# Altium schematic sheet Temp_Zasilanie.SchDoc(Temp_Zasilanie)
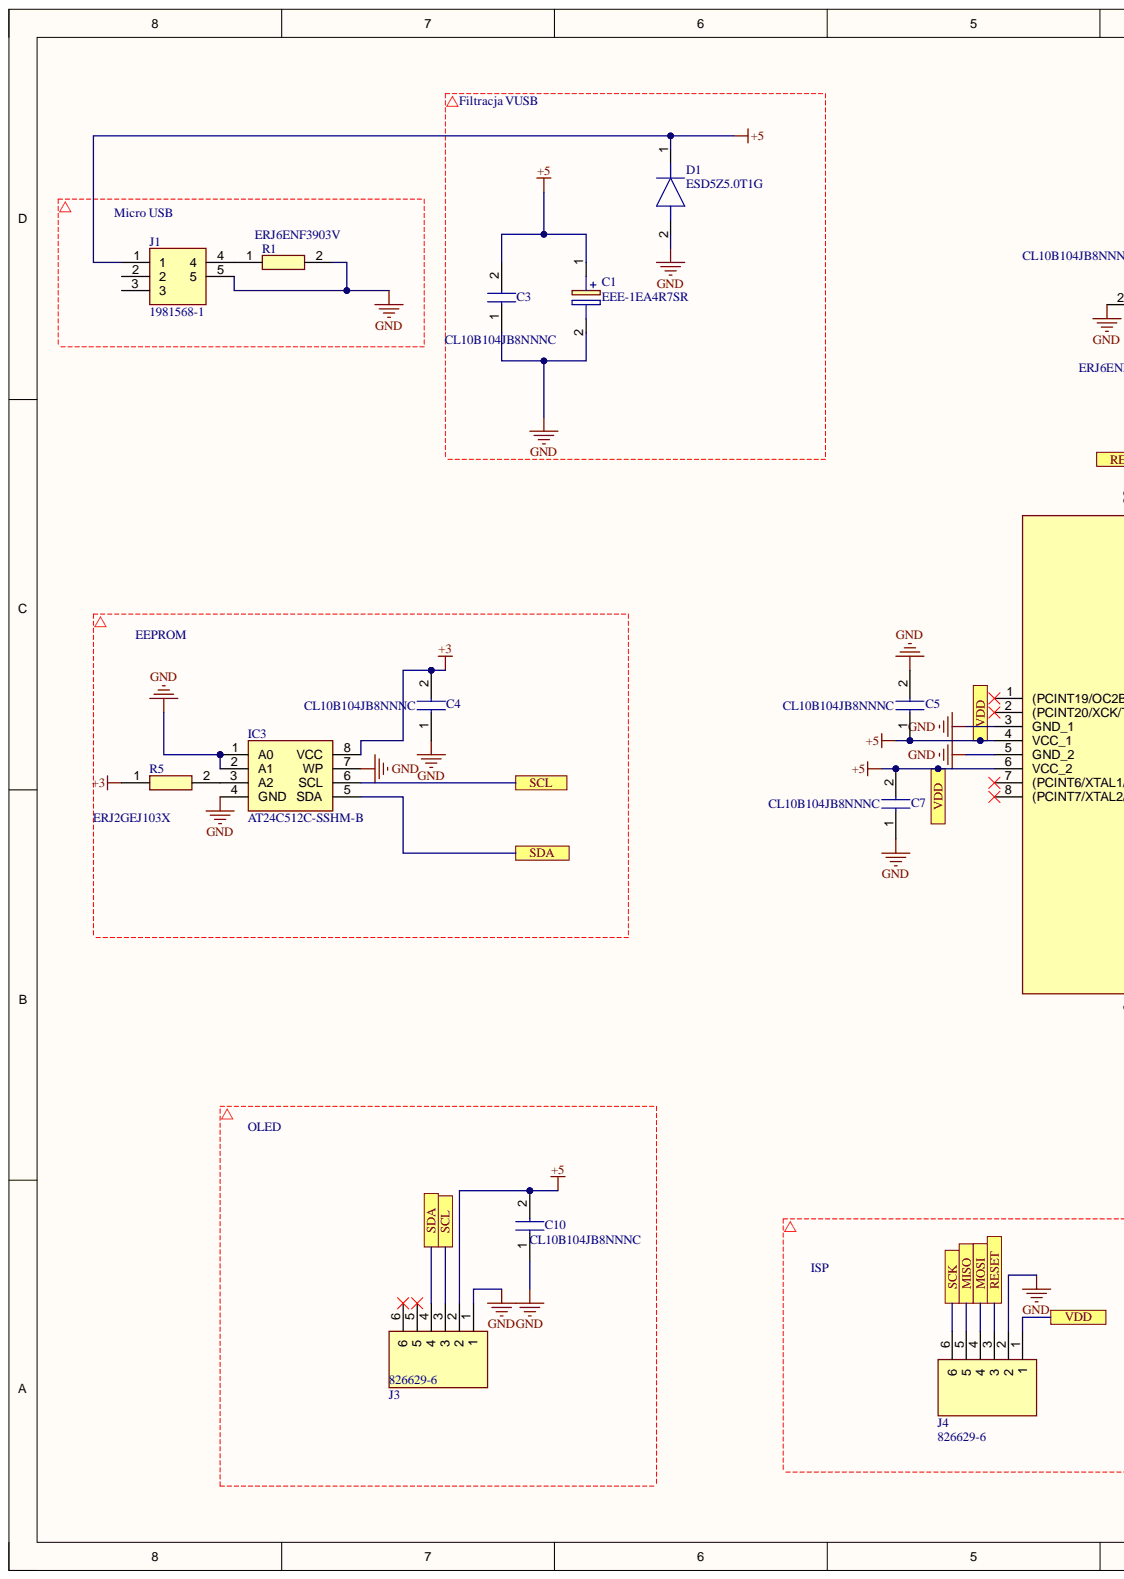
[diagram p0: Temp_Zasilanie.SchDoc, left]
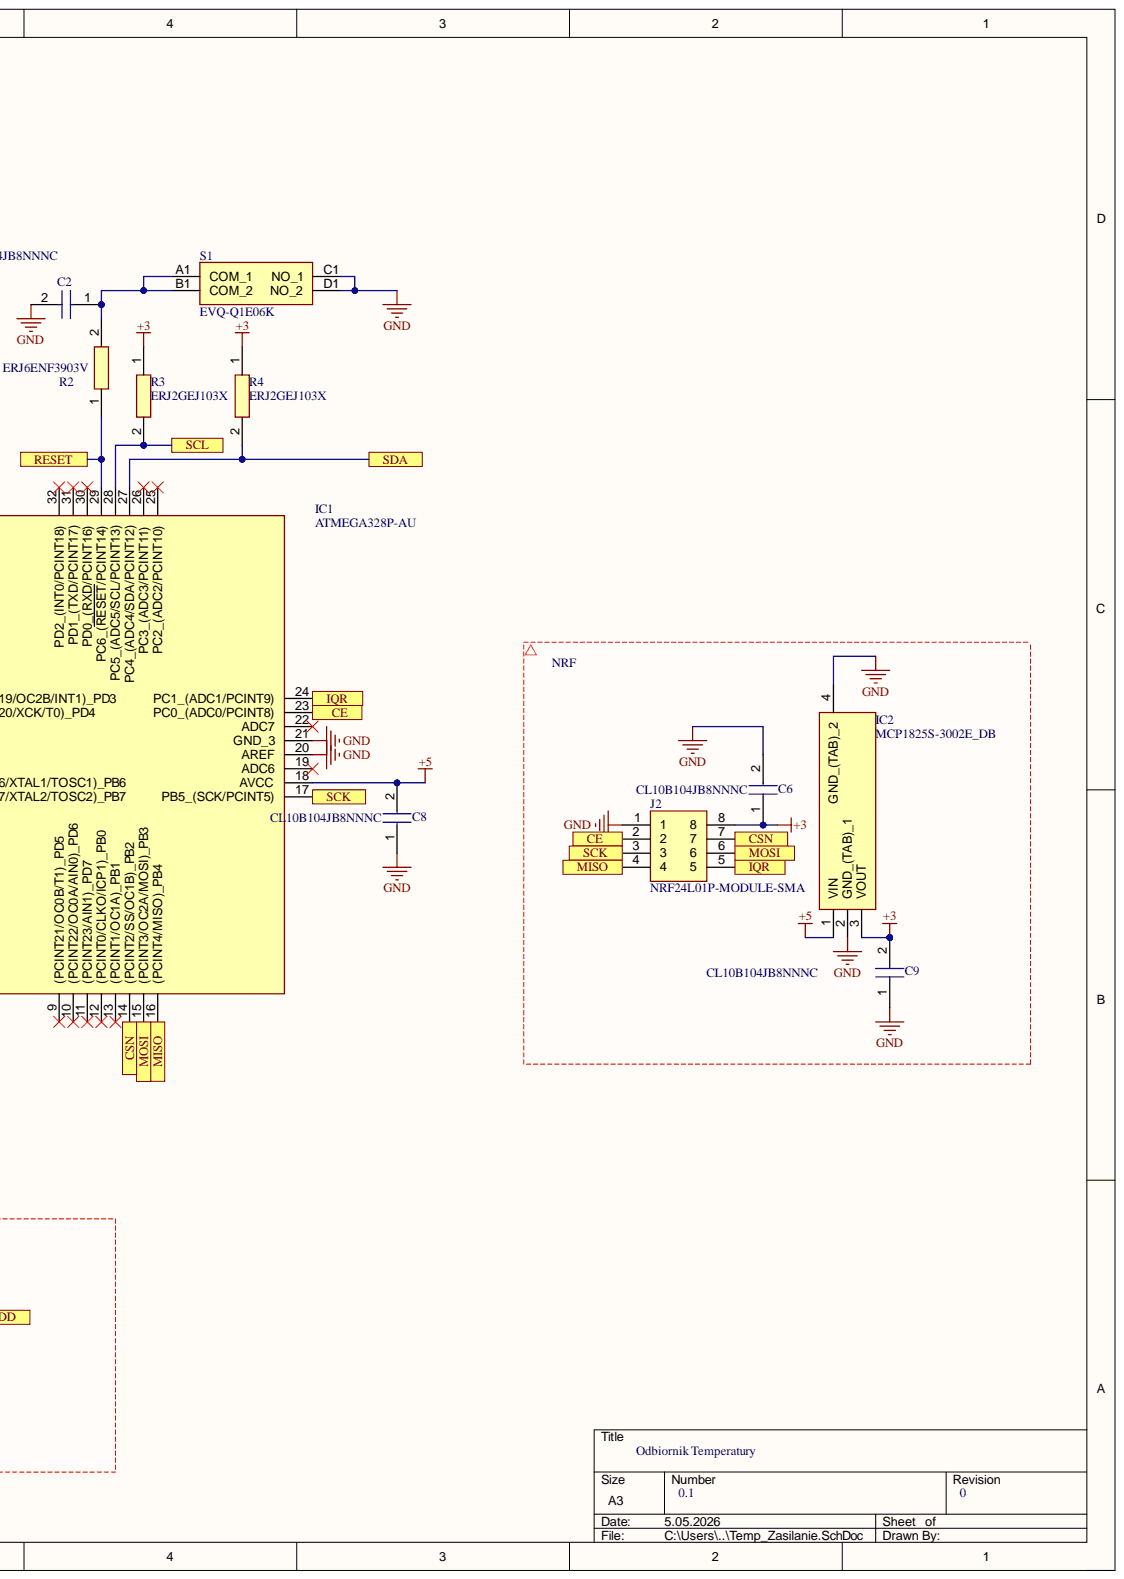
[diagram p1: Temp_Zasilanie.SchDoc, right]

## Parts
C1  EEE-1EA4R7SR  [EEE1AA220WR]
C2  CL10B104JB8NNNC  [CAPC1608X90N]
C3  CL10B104JB8NNNC  [CAPC1608X90N]
C4  CL10B104JB8NNNC  [CAPC1608X90N]
C5  CL10B104JB8NNNC  [CAPC1608X90N]
C6  CL10B104JB8NNNC  [CAPC1608X90N]
C7  CL10B104JB8NNNC  [CAPC1608X90N]
C8  CL10B104JB8NNNC  [CAPC1608X90N]
C9  CL10B104JB8NNNC  [CAPC1608X90N]
C10  CL10B104JB8NNNC  [CAPC1608X90N]
D1  ESD5Z5.0T1G  [SODFL1608X70N]
IC1  ATMEGA328P-AU  [QFP80P900X900X120-32N]
IC2  MCP1825S-3002E_DB  [SOT230P700X180-4N]
IC3  AT24C512C-SSHM-B  [SOIC127P600X175-8N]
J1  1981568-1  [1981568-1]
J2  NRF24L01P-MODULE-SMA  [NRF24L01PMODULESMA]
J3  826629-6  [HDRV6W63P0X254_1X6_1524X250X950P]
J4  826629-6  [HDRV6W63P0X254_1X6_1524X250X950P]
R1  ERJ6ENF3903V  [ERJ6ENF1000V]
R2  ERJ6ENF3903V  [ERJ6ENF1000V]
R3  ERJ2GEJ103X  [ERJ2RKD1004X]
R4  ERJ2GEJ103X  [ERJ2RKD1004X]
R5  ERJ2GEJ103X  [ERJ2RKD1004X]
S1  EVQ-Q1E06K  [EVQQ1E06K]

## Ports
CE, CSN, IQR, MISO, MOSI, RESET, SCK, SCL, SDA, VDD

## Nets
+3: C4.2 C6.1 C9.2 IC2.3(VOUT) IC3.8(VCC) J2.8 R3.1 R4.1 R5.1
GND: C1.2 C2.2 C3.1 C4.1 C5.2 C6.2 C7.1 C8.1 C9.1 C10.1 D1.2 IC1.3(GND_1) IC1.5(GND_2) IC1.20(AREF) IC1.21(GND_3) IC2.2(GND_(TAB)_1) IC2.4(GND_(TAB)_2) IC3.1(A0) IC3.2(A1) IC3.4(GND) IC3.7(WP) J1.5 J2.1 J3.1 J4.2 R1.2 S1.C1(NO_1) S1.D1(NO_2)
+5: C1.1 C3.2 C5.1 C7.2 C8.2 C10.2 D1.1 IC1.4(VCC_1) IC1.6(VCC_2) IC1.18(AVCC) IC2.1(VIN) J1.1 J3.2 J4.1 ; port VDD
CE: IC1.23(PC0_(ADC0/PCINT8)) J2.2 ; port CE
CSN: IC1.14((PCINT2/SS/OC1B)_PB2) J2.7 ; port CSN
IQR: IC1.24(PC1_(ADC1/PCINT9)) J2.5 ; port IQR
MISO: IC1.16((PCINT4/MISO)_PB4) J2.4 J4.5 ; port MISO
MOSI: IC1.15((PCINT3/OC2A/MOSI)_PB3) J2.6 J4.4 ; port MOSI
RESET: IC1.29(PC6_(RESET/PCINT14)) J4.3 R2.1 ; port RESET
SCK: IC1.17(PB5_(SCK/PCINT5)) J2.3 J4.6 ; port SCK
SCL: IC1.28(PC5_(ADC5/SCL/PCINT13)) IC3.6(SCL) J3.3 R3.2 ; port SCL
SDA: IC1.27(PC4_(ADC4/SDA/PCINT12)) IC3.5(SDA) J3.4 R4.2 ; port SDA
NetC2_1: C2.1 R2.2 S1.A1(COM_1) S1.B1(COM_2)
NetIC3_3: IC3.3(A2) R5.2
NetJ1_4: J1.4 R1.1

Not connected: IC1 1((PCINT19/OC2B/INT1)_PD3) 2((PCINT20/XCK/T0)_PD4) 7((PCINT6/XTAL1/TOSC1)_PB6) 8((PCINT7/XTAL2/TOSC2)_PB7) 9((PCINT21/OC0B/T1)_PD5) 10((PCINT22/OC0A/AIN0)_PD6) 11((PCINT23/AIN1)_PD7) 12((PCINT0/CLKO/ICP1)_PB0) 13((PCINT1/OC1A)_PB1) 19(ADC6) 22(ADC7) 25(PC2_(ADC2/PCINT10)) 26(PC3_(ADC3/PCINT11)) 30(PD0_(RXD/PCINT16)) 31(PD1_(TXD/PCINT17)) 32(PD2_(INT0/PCINT18)); J1 2 3; J3 5 6

## Library data of the parts
C1 = EEE-1EA4R7SR: Capacitor Polarised; Manufacturer_Name: Panasonic; Arrow Part Number: EEE-1EA4R7SR; Arrow Price/Stock: https://www.arrow.com/en/products/eee-1ea4r7sr/panasonic?region=nac; Component Kind: Standard; Datasheet Link: http://industrial.panasonic.com/cdbs/www-data/pdf/RDE0000/ABA0000C1145.pdf; Height: 5.5mm; Manufacturer_Part_Number: EEE-1EA4R7SR; Mouser Part Number: 667-EEE-1EA4R7SR; Mouser Price/Stock: https://www.mouser.co.uk/ProductDetail/Panasonic/EEE-1EA4R7SR?qs=ANJC8C65Mw5Ie6rFZ1MXbA%3D%3D; Signal Integrity: Guessed Model
C2-C10 = CL10B104JB8NNNC: Capacitor; Manufacturer_Name: SAMSUNG; Arrow Part Number: CL10B104JB8NNNC; Arrow Price/Stock: https://www.arrow.com/en/products/cl10b104jb8nnnc/samsung-electro-mechanics?region=nac; Component Kind: Standard; Datasheet Link: https://datasheet.datasheetarchive.com/originals/distributors/Datasheets_SAMA/dd149ce8a6679b37799cedb31427d43d.pdf; Height: 0.9mm; Manufacturer_Part_Number: CL10B104JB8NNNC; Mouser Part Number: 187-CL10B104JB8NNNC; Mouser Price/Stock: https://www.mouser.co.uk/ProductDetail/Samsung-Electro-Mechanics/CL10B104JB8NNNC?qs=349EhDEZ59oLEw5wc1qvGw%3D%3D
  C2-C7: Signal Integrity: Guessed Model
D1 = ESD5Z5.0T1G: Diode; Manufacturer_Name: onsemi; Arrow Part Number: ESD5Z5.0T1G; Arrow Price/Stock: https://www.arrow.com/en/products/esd5z5.0t1g/on-semiconductor?region=nac; Component Kind: Standard; Datasheet Link: http://www.onsemi.com/pub/Collateral/ESD5Z2.5T1-D.PDF; Height: 0.7mm; Library Name: SamacSys.SchLib; Manufacturer_Part_Number: ESD5Z5.0T1G
IC1 = ATMEGA328P-AU: Integrated Circuit; Manufacturer_Name: Microchip; Arrow Part Number: ATMEGA328P-AU; Arrow Price/Stock: https://www.arrow.com/en/products/atmega328p-au/microchip-technology?region=europe; Component Kind: Standard; Datasheet Link: https://componentsearchengine.com/Datasheets/2/ATMEGA328P-AU.pdf; Height: 1.2mm; Manufacturer_Part_Number: ATMEGA328P-AU; Mouser Part Number: 556-ATMEGA328P-AU; Mouser Price/Stock: https://www.mouser.co.uk/ProductDetail/Microchip-Technology/ATMEGA328P-AU?qs=K8BHR703ZXiCmmgp6%2FGNmQ%3D%3D
IC2 = MCP1825S-3002E_DB: Integrated Circuit; Manufacturer_Name: Microchip; Manufacturer_Part_Number: MCP1825S-3002E/DB; Arrow Part Number: MCP1825S-3002E/DB; Arrow Price/Stock: https://www.arrow.com/en/products/mcp1825s-3002edb/microchip-technology?region=nac; Component Kind: Standard; Datasheet Link: http://ww1.microchip.com/downloads/en/DeviceDoc/22056b.pdf; Height: 1.8mm; Mouser Part Number: 579-MCP1825S-3002EDB; Mouser Price/Stock: https://www.mouser.co.uk/ProductDetail/Microchip-Technology/MCP1825S-3002E-DB?qs=gsqZ4L1luKo7Ksvo0w2QHA%3D%3D
IC3 = AT24C512C-SSHM-B: Integrated Circuit; Manufacturer_Name: Microchip; Arrow Part Number: AT24C512C-SSHM-B; Arrow Price/Stock: https://www.arrow.com/en/products/at24c512c-sshm-b/microchip-technology?region=europe; Component Kind: Standard; Datasheet Link: http://ww1.microchip.com/downloads/en/devicedoc/atmel-8720-seeprom-at24c512c-datasheet.pdf; Height: 1.75mm; Manufacturer_Part_Number: AT24C512C-SSHM-B; Mouser Part Number: 556-AT24C512C-SSHM-B; Mouser Price/Stock: https://www.mouser.co.uk/ProductDetail/Microchip-Technology/AT24C512C-SSHM-B?qs=rNaVQffb%252BtdhEtod1Bl9yw%3D%3D
J1 = 1981568-1: Connector; Manufacturer_Name: TE Connectivity; Arrow Part Number: 1981568-1; Arrow Price/Stock: https://www.arrow.com/en/products/1981568-1/te-connectivity?region=nac; Component Kind: Standard; Datasheet Link: https://www.te.com/commerce/DocumentDelivery/DDEController?Action=srchrtrv&DocNm=5-1773464-3&DocType=Data%20Sheet&DocLang=English&PartCntxt=1981568-1&DocFormat=pdf; Manufacturer_Part_Number: 1981568-1; Mouser Part Number: 571-1981568-1; Mouser Price/Stock: https://www.mouser.co.uk/ProductDetail/TE-Connectivity/1981568-1?qs=zxTme0yW%2FbaAfvOKTKC5hw%3D%3D
J2 = NRF24L01P-MODULE-SMA: Connector; Manufacturer_Name: Nordic Semiconductor; Arrow Part Number: NRF24L01P-MODULE-SMA; Arrow Price/Stock: https://www.arrow.com/en/products/nrf24l01p-module-sma/nordic-semiconductor?region=nac; Component Kind: Standard; Datasheet Link: https://infocenter.nordicsemi.com/pdf/nRF24L01P_PS_v1.0.pdf; Height: 4mm; Library Name: SamacSys.SchLib; Manufacturer_Part_Number: NRF24L01P-MODULE-SMA
J3 J4 = 826629-6: Connector; Manufacturer_Name: TE Connectivity; Arrow Part Number: 826629-6; Arrow Price/Stock: https://www.arrow.com/en/products/826629-6/te-connectivity?region=europe; Component Kind: Standard; Datasheet Link: https://componentsearchengine.com/Datasheets/2/826629-6.pdf; Height: 9.5mm; Library Name: SamacSys.SchLib; Manufacturer_Part_Number: 826629-6
R1 R2 = ERJ6ENF3903V: Resistor; Manufacturer_Name: Panasonic; Component Kind: Standard; Datasheet Link: http://docs-europe.electrocomponents.com/webdocs/13ba/0900766b813ba21b.pdf; Height: 0.7mm; Manufacturer_Part_Number: ERJ6ENF3903V
  R1: Library Name: SamacSys.SchLib
  R2: Simulation: R_Resistor_Default
R3-R5 = ERJ-2GEJ3R3X: Resistor; Manufacturer_Name: Panasonic; Arrow Part Number: ERJ-2GEJ3R3X; Arrow Price/Stock: https://www.arrow.com/en/products/erj-2gej3r3x/panasonic; Component Kind: Standard; Datasheet Link: https://datasheet.datasheetarchive.com/originals/distributors/DKDS40/DSANUWW0010666.pdf; Height: 0.4mm; Library Name: SamacSys.SchLib; Manufacturer_Part_Number: ERJ-2GEJ3R3X; Mouser Part Number: 667-ERJ-2GEJ3R3X; Mouser Price/Stock: https://www.mouser.co.uk/ProductDetail/Panasonic/ERJ-2GEJ3R3X?qs=YMBIwoNQYdWx1%2FesbGSybw%3D%3D
S1 = EVQ-Q1E06K: Switch; Manufacturer_Name: Panasonic; Arrow Part Number: EVQ-Q1E06K; Arrow Price/Stock: https://www.arrow.com/en/products/evq-q1e06k/panasonic; Component Kind: Standard; Datasheet Link: https://b2b-api.panasonic.eu/file_stream/pids/fileversion/245489; Height: 6.7mm; Library Name: SamacSys.SchLib; Manufacturer_Part_Number: EVQ-Q1E06K; Mouser Part Number: 667-EVQ-Q1E06K; Mouser Price/Stock: https://www.mouser.co.uk/ProductDetail/Panasonic/EVQ-Q1E06K?qs=iurMsj5%2FK0sLNNXJSdosvw%3D%3D
